# Altium schematic sheet TIDA-01349-Interlock-Card.SchDoc(TIDA-01349-Interlock-Card)
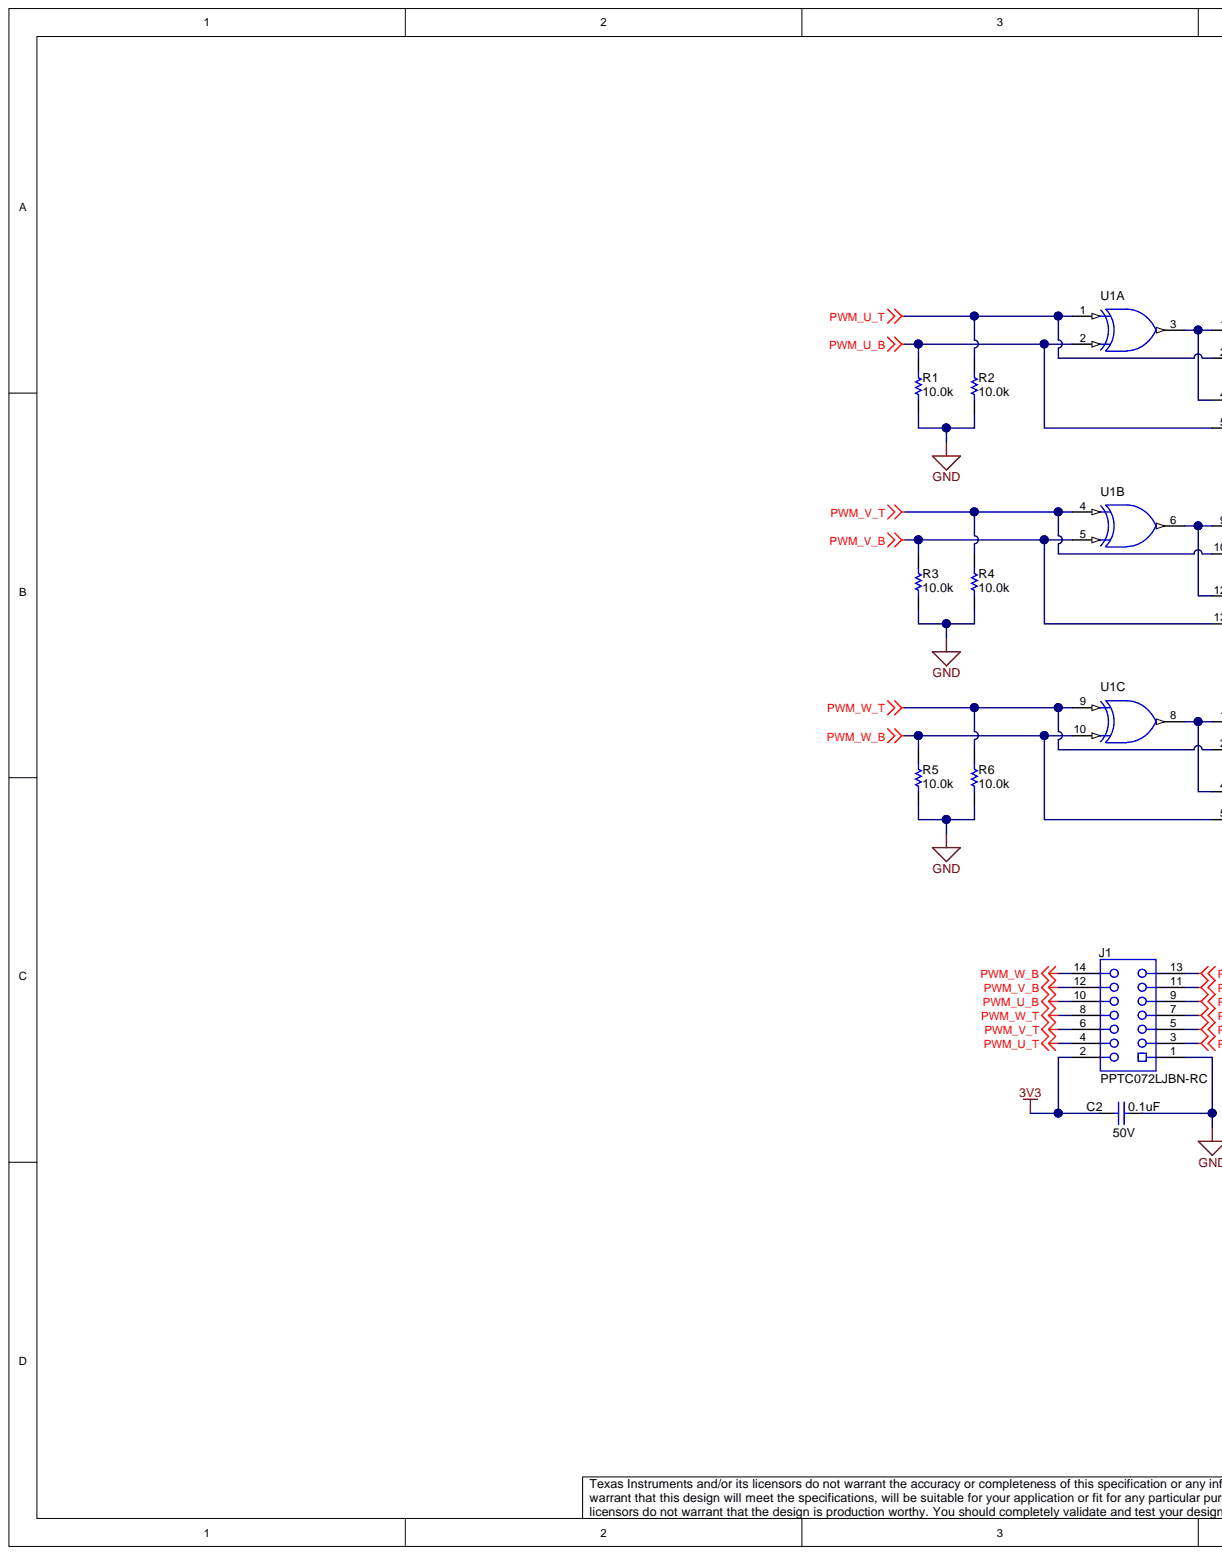
[diagram p0: TIDA-01349-Interlock-Card.SchDoc, left]
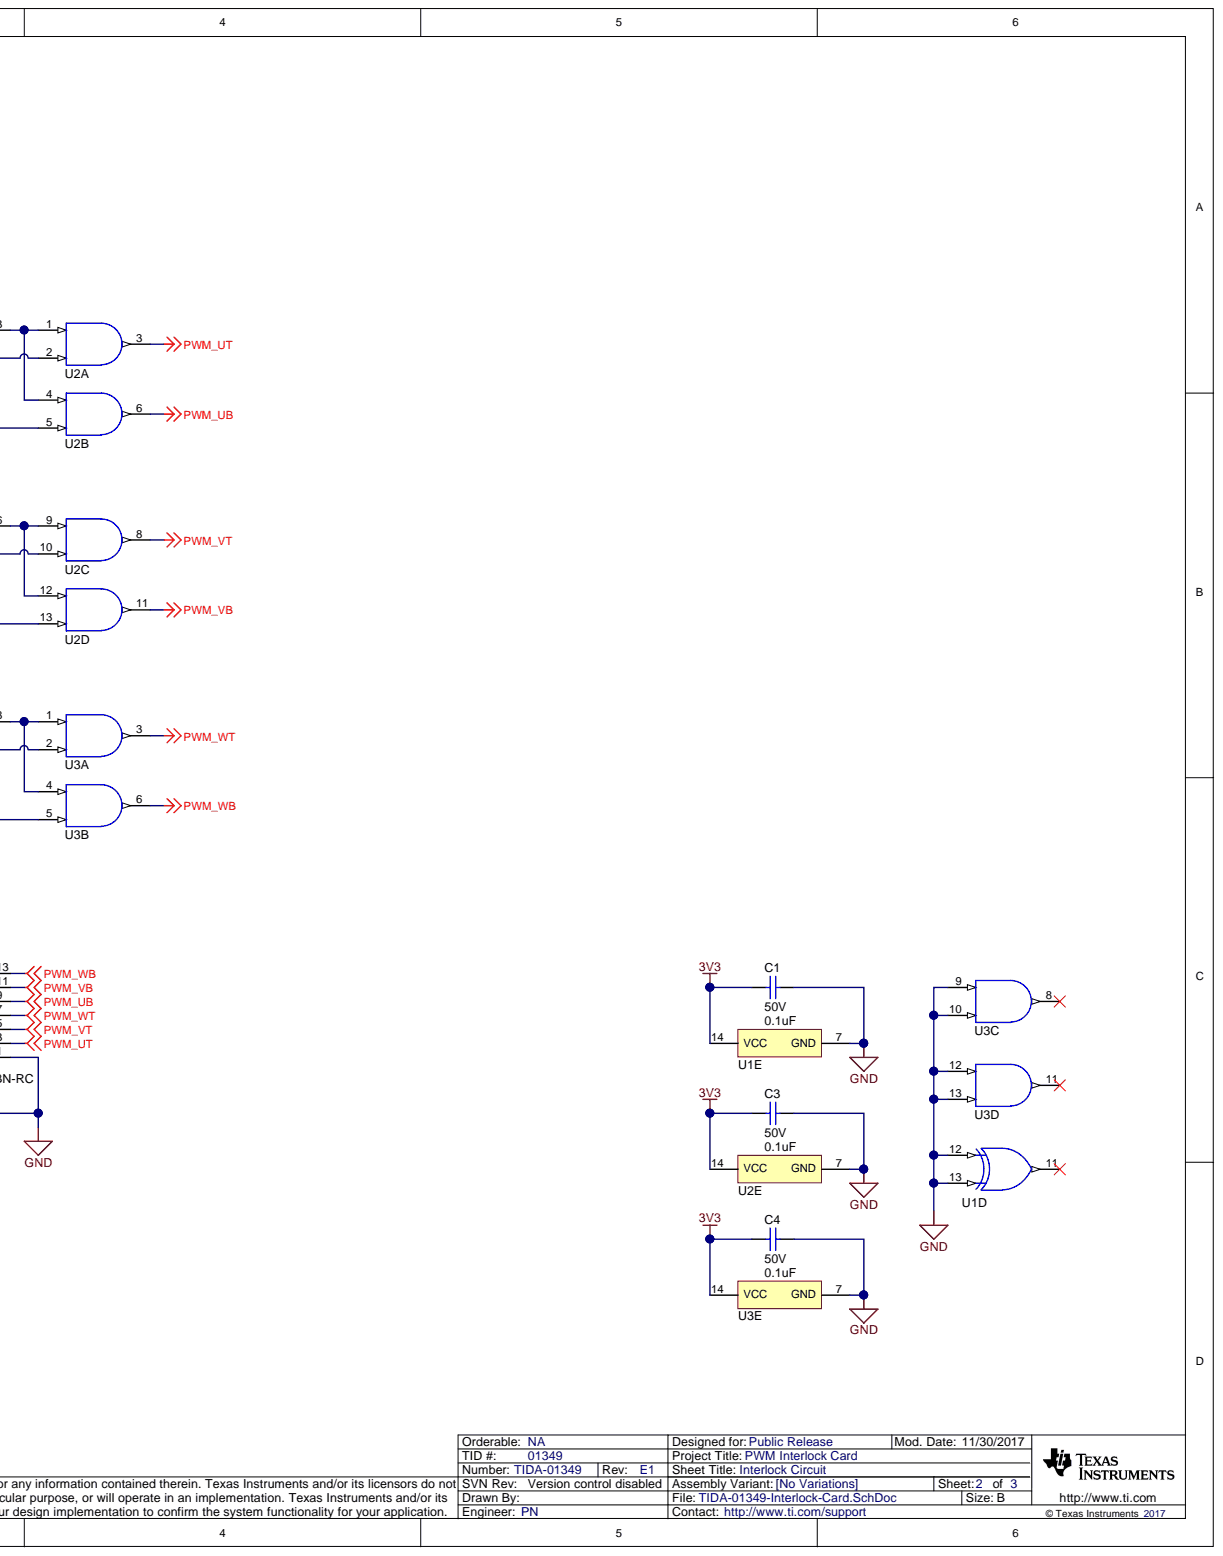
[diagram p1: TIDA-01349-Interlock-Card.SchDoc, right]

## Parts
C1  value 0.1uF  VDC_V: 50V  [0603]  (hidden comment: C0603C104K5RACTU)
C2  value 0.1uF  VDC_V: 50V  ValueDisplayed: 0.1uF  [0603]  (hidden comment: C0603C104K5RACTU)
C3  value 0.1uF  VDC_V: 50V  [0603]  (hidden comment: C0603C104K5RACTU)
C4  value 0.1uF  VDC_V: 50V  [0603]  (hidden comment: C0603C104K5RACTU)
J1  PPTC072LJBN-RC  [Sullins_xPxC072LJBN]
R1  value 10.0k  ValueDisplayed: 10.0k  [0402]  (hidden comment: CRCW040210K0FKED)
R2  value 10.0k  ValueDisplayed: 10.0k  [0402]  (hidden comment: CRCW040210K0FKED)
R3  value 10.0k  ValueDisplayed: 10.0k  [0402]  (hidden comment: CRCW040210K0FKED)
R4  value 10.0k  ValueDisplayed: 10.0k  [0402]  (hidden comment: CRCW040210K0FKED)
R5  value 10.0k  ValueDisplayed: 10.0k  [0402]  (hidden comment: CRCW040210K0FKED)
R6  value 10.0k  ValueDisplayed: 10.0k  [0402]  (hidden comment: CRCW040210K0FKED)
U1  SN74LVC86APW  [PW0014A_N]  (value not drawn)  (parts A,B,C,D,E)
U2  SN74LVC08APW  [PW0014A_N]  (value not drawn)  (parts A,B,C,D,E)
U3  SN74LVC08APW  [PW0014A_N]  (value not drawn)  (parts A,B,C,D,E)

## Nets
3V3: C1.2 C2.2 C3.2 C4.2 J1.2 U1.14(VCC) U2.14(VCC) U3.14(VCC)
GND: C1.1 C2.1 C3.1 C4.1 J1.1 R1.1 R2.1 R3.1 R4.1 R5.1 R6.1 U1.7(GND) U1.12 U1.13 U2.7(GND) U3.7(GND) U3.9 U3.10 U3.12 U3.13
PWM_U_B: J1.10 R1.2 U1.2 U2.5
PWM_U_T: J1.4 R2.2 U1.1 U2.2
PWM_UB: J1.9 U2.6
PWM_UT: J1.3 U2.3
PWM_V_B: J1.12 R3.2 U1.5 U2.13
PWM_V_T: J1.6 R4.2 U1.4 U2.10
PWM_VB: J1.11 U2.11
PWM_VT: J1.5 U2.8
PWM_W_B: J1.14 R5.2 U1.10 U3.5
PWM_W_T: J1.8 R6.2 U1.9 U3.2
PWM_WB: J1.13 U3.6
PWM_WT: J1.7 U3.3
NetU1_3: U1.3 U2.1 U2.4
NetU1_6: U1.6 U2.9 U2.12
NetU1_8: U1.8 U3.1 U3.4

Not connected: U1 11; U3 8 11

## Library data of the parts
C1 C3 C4 = CMP-0006861-2: CAP, CERM, 0.1 uF, 50 V, +/- 10%, X7R, 0603; Manufacturer: Kemet; ValueDisplayed: 0.1uF; Value1: Unknown; Value: 0.1uF; U/M: EA; TaMin_C: -55°C; TaMax_C: 125°C; SizeZ_mm: 1; SizeY_mm: 0.8; SizeX_mm: 1.6; SizeAreaWB_mm2: 4.68; SizeArea_mm2: 1.28; Polarized: N; CompType: Capacitor; Cap_F: 100nF; AEC Category: Q200; Value2: 50V; Tolerance_%: 10%; TempCo: X7R; Technology: Ceramic; Supplier Part Number 3: 1288255; Supplier Part Number 2: 80-C0603C104K5R; Supplier Part Number 1: 399-5089-1-ND; Supplier 3: Farnell; Supplier 2: Mouser; Supplier 1: Digi-Key; RoHS: Y; Published: 2008-06-19; PartNumber: C0603C104K5RACTU; PackageReference: 0603; MountType: SMT; Library Name: Altium Vault - TI; LatestRevisionDate: 2017-03-09; ComponentLink1URL: http://www.kemet.com/kemet/web/homepage/kechome.nsf/vapubfiles/F3102_Y5V.pdf/$file/F3102_Y5V.pdf; ComponentLink1Description: Datasheet link; Component Kind: Standard; Cap_Case: 0603; AEC Qualified: ?; Publisher: Texas Instruments
C2 = CMP-0006861-2: CAP, CERM, 0.1 uF, 50 V, +/- 10%, X7R, 0603; Manufacturer: Kemet; Value1: Unknown; U/M: EA; TaMin_C: -55°C; TaMax_C: 125°C; SizeZ_mm: 1; SizeY_mm: 0.8; SizeX_mm: 1.6; SizeAreaWB_mm2: 4.68; SizeArea_mm2: 1.28; Polarized: N; CompType: Capacitor; Cap_F: 100nF; AEC Category: Q200; Value2: 50V; Tolerance_%: 10%; TempCo: X7R; Technology: Ceramic; Supplier Part Number 3: 1288255; Supplier Part Number 2: 80-C0603C104K5R; Supplier Part Number 1: 399-5089-1-ND; Supplier 3: Farnell; Supplier 2: Mouser; Supplier 1: Digi-Key; RoHS: Y; Published: 2008-06-19; PartNumber: C0603C104K5RACTU; PackageReference: 0603; MountType: SMT; Library Name: Altium Vault - TI; LatestRevisionDate: 2017-03-09; ComponentLink1URL: http://www.kemet.com/kemet/web/homepage/kechome.nsf/vapubfiles/F3102_Y5V.pdf/$file/F3102_Y5V.pdf; ComponentLink1Description: Datasheet link; Component Kind: Standard; Cap_Case: 0603; AEC Qualified: ?; Publisher: Texas Instruments
J1 = CMP-0054673-1: Receptacle, 2.54mm, 7x2, Tin, Black, R/A, TH; Manufacturer: Sullins Connector Solutions; Voltage_V: 250V; RowSpacing_mm: 2.54; Row_Count: 2; Gender: Female; Current_A: 3A; CT: TRUE; CompType: Socket Strip; CNHeader: FALSE; Cert_UL: Y; Supplier Part Number 1: S5521-ND; Supplier 1: Digi-Key; RoHS: Y; Pitch_mm: 2.54; PinCount: 14; PartNumber: PPTC072LJBN-RC; PackageReference: Receptacle, 2.54mm, 7x2, R/A, TH; Orientation: R/A; MountType: TH; Library Name: Altium Vault - TI; LatestRevisionDate: 26/05/2017; ContactFinish: Tin; ComponentLink1URL: http://sullinscorp.com/drawings/101_P(N)PxCxx2LJBN-RC,_10494-H.pdf; ComponentLink1Description: Datasheet URL; Component Kind: Standard; Color: Black; Publisher: Texas Instruments
R1-R6 = CMP-0025958-2: RES, 10.0 k, 1%, 0.063 W, 0402; Manufacturer: Vishay-Dale; VDC_V: 50V; Value_Code: 10k0; Value2: 0.063W; U/M: EA; TempCo: 100 ppm/C; SizeZ_mm: 0.6; SizeY_mm: 0.5; SizeX_mm: 1; Res_ohm: 10k; Power_W: 63mW; EIA_Code: E96; CompType: Resistor; AEC Category: Q200; Value1: 1%; Tolerance_%: 1%; Technology: Thick Film; Supplier Part Number 3: 71-CRCW0402-10K-E3; Supplier Part Number 2: 52K6595; Supplier Part Number 1: 541-10.0KLCT-ND; Supplier 3: Mouser; Supplier 2: Newark; Supplier 1: Digi-Key; SizeArea_mm2: 0.5; RoHS: Y; Published: 2008-06-26; PartNumber: CRCW040210K0FKED; PackageReference: 0402; MountType: SMT; Library Name: Altium Vault - TI; LatestRevisionDate: 2017-03-09; ComponentLink1URL: http://www.vishay.com/docs/20035/dcrcwe3.pdf; ComponentLink1Description: Datasheet link; Component Kind: Standard; AEC Qualified: ?; Publisher: Texas Instruments
U1 = CMP-0053460-1: Quadruple 2-Input Exclusive-OR Gate, PW0014A, TUBE; Manufacturer: Texas Instruments; Voltage(Nom)(V): 1.8,2.5,2.7,3.3; VCC(Min)(V): 1.65; VCC(Max)(V): 3.6; tpd @ Nom Voltage(Max)(ns): 9.9,7.6,5.6,4.6; Technology Family: LVC; Schmitt Trigger: No; Rating: Catalog; Output Type: TTL; Output Drive (IOL/IOH)(Max)(mA): 24/-24; ICC @ Nom Voltage(Max)(mA): 0.01; F @ Nom Voltage(Max)(Mhz): 70; Bits(#): 4; PartId: 1; PackageReference: PW0014A; Operating Temperature Range(C): -40 to 125; Library Name: Altium Vault - TI; Input Type: TTL; Generic Part Number: SN74LVC86A; DatasheetVersion: SCAS288P; Component Kind: Standard
U2 U3 = CMP-0054498-1: Quadruple 2-Input Positive-AND Gate, PW0014A, TUBE; Manufacturer: Texas Instruments; Voltage(Nom)(V): 1.8,2.5,2.7,3.3; VCC(Min)(V): 1.65; VCC(Max)(V): 3.6; tpd @ Nom Voltage(Max)(ns): 9.8,6.9,4.8,4.1; Technology Family: LVC; Schmitt Trigger: No; Rating: Catalog; Output Type: TTL; Output Drive (IOL/IOH)(Max)(mA): -24/24; ICC @ Nom Voltage(Max)(mA): 0.01; F @ Nom Voltage(Max)(Mhz): 70; Bits(#): 4; PartId: 1; PackageReference: PW0014A; Operating Temperature Range(C): -40 to 125; Library Name: Altium Vault - TI; Input Type: TTL; Generic Part Number: SN74LVC08A; DatasheetVersion: SCAS283Q; Component Kind: Standard
